# Altium schematic sheet Buck 5V.SchDoc
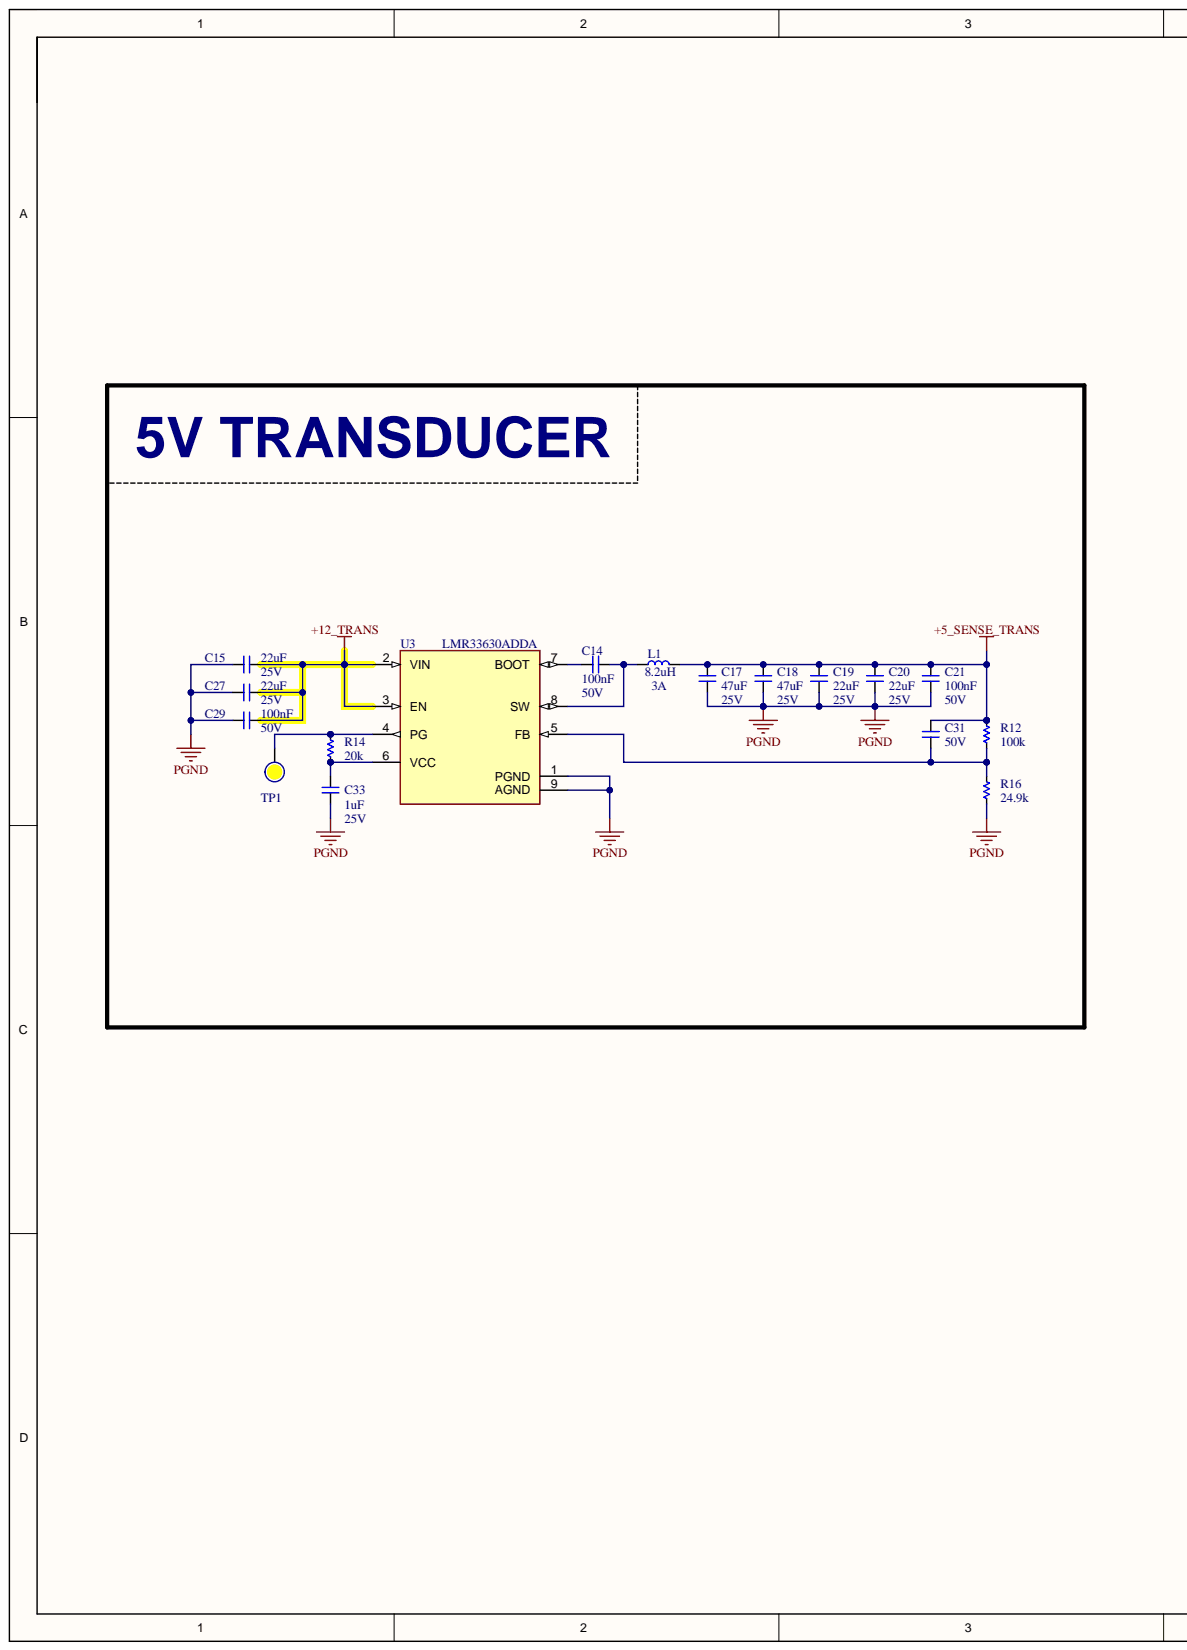
[diagram p0: Buck 5V.SchDoc, left]
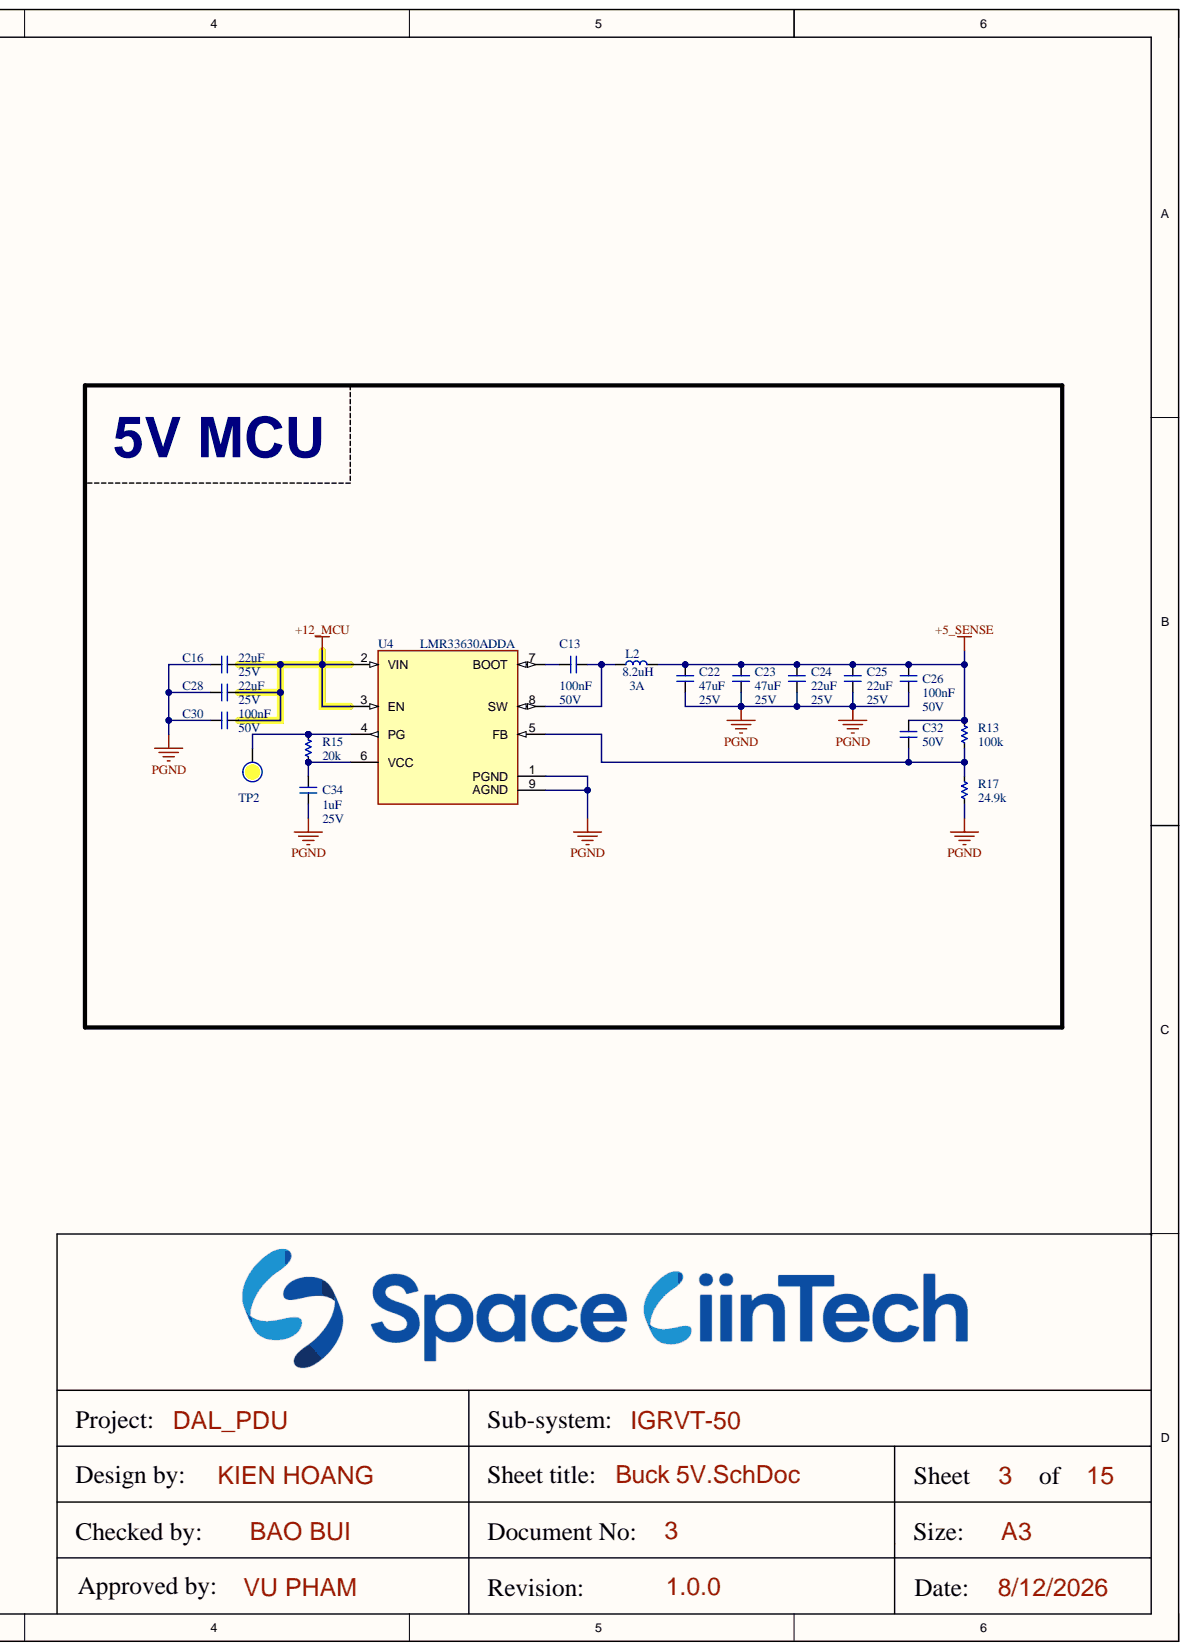
[diagram p1: Buck 5V.SchDoc, right]

## Parts
C13  100nF  [CAP_C_1608X80]
C14  100nF  Voltage: 50V  [CAP_C_1608X80]
C15  22uF  Voltage: 25V  [CAP_C_2012X110]
C16  22uF  Voltage: 25V  [CAP_C_2012X110]
C17  47uF  Voltage: 25V  [CAP_C_3216X140]
C18  47uF  Voltage: 25V  [CAP_C_3216X140]
C19  22uF  Voltage: 25V  [CAP_C_2012X110]
C20  22uF  Voltage: 25V  [CAP_C_2012X110]
C21  100nF  Voltage: 50V  [CAP_C_1608X80]
C22  47uF  Voltage: 25V  [CAP_C_3216X140]
C23  47uF  Voltage: 25V  [CAP_C_3216X140]
C24  22uF  Voltage: 25V  [CAP_C_2012X110]
C25  22uF  Voltage: 25V  [CAP_C_2012X110]
C26  100nF  Voltage: 50V  [CAP_C_1608X80]
C27  22uF  Voltage: 25V  [CAP_C_2012X110]
C28  22uF  Voltage: 25V  [CAP_C_2012X110]
C29  100nF  Voltage: 50V  [CAP_C_1608X80]
C30  100nF  Voltage: 50V  [CAP_C_1608X80]
C31  Voltage: 50V  [CAP_C_1608X80]  (hidden comment: DNP/100nF)
C32  100nF  Voltage: 50V  [CAP_C_1608X80]
C33  1uF  Voltage: 25V  [CAP_C_1608X80]
C34  1uF  Voltage: 25V  [CAP_C_1608X80]
L1  8.2uH  Voltage: 3A  [INDUCTOR 6363]
L2  8.2uH  Voltage: 3A  [INDUCTOR 6363]
R12  100k  [RES_C_1608X60]
R13  100k  [RES_C_1608X60]
R14  20k  [RES_C_1608X60]
R15  20k  [RES_C_1608X60]
R16  24.9k  [RES_C_1608X60]
R17  24.9k  [RES_C_1608X60]
TP1  (no value)  [TP_1mm_SMD]  (no BOM)
TP2  (no value)  [TP_1mm_SMD]  (no BOM)
U3  LMR33630ADDA  [HSOIC_8]
U4  LMR33630ADDA  [HSOIC_8]

## Nets
+5_SENSE: C22.1 C23.1 C24.1 C25.1 C26.1 C32.1 L2.2 R13.1
+5_SENSE_TRANS: C17.1 C18.1 C19.1 C20.1 C21.1 C31.1 L1.2 R12.1
+12_MCU: C16.1 C28.1 C30.1 U4.2(VIN) U4.3(EN)
+12_TRANS: C15.1 C27.1 C29.1 U3.2(VIN) U3.3(EN)
PGND: C15.2 C16.2 C17.2 C18.2 C19.2 C20.2 C21.2 C22.2 C23.2 C24.2 C25.2 C26.2 C27.2 C28.2 C29.2 C30.2 C33.2 C34.2 R16.2 R17.2 U3.1(PGND) U3.9(AGND) U4.1(PGND) U4.9(AGND)
NetC13_1: C13.1 L2.1 U4.8(SW)
NetC13_2: C13.2 U4.7(BOOT)
NetC14_1: C14.1 L1.1 U3.8(SW)
NetC14_2: C14.2 U3.7(BOOT)
NetC31_2: C31.2 R12.2 R16.1 U3.5(FB)
NetC32_2: C32.2 R13.2 R17.1 U4.5(FB)
NetC33_1: C33.1 R14.2 U3.6(VCC)
NetC34_1: C34.1 R15.2 U4.6(VCC)
NetR14_1: R14.1 TP1.1 U3.4(PG)
NetR15_1: R15.1 TP2.1 U4.4(PG)

## Library data of the parts
C13 = _CAP_C_2: CAP; Voltage: 50V; Component Kind: Standard; Library Name: LABLIB.SchLib
C14-C34 = _CAP_C_2: CAP; Component Kind: Standard; Library Name: LABLIB.SchLib
L1 L2 = Inductor: Component Kind: Standard; Description: Inductor; Library Name: KIENASIY123_LIB.IntLib
R12-R17 = _RES_B_2_2: RES; Component Kind: Standard; Library Name: LABLIB.SchLib
TP1 TP2 = Test Point: Solder: NF; Description: Test Point; Library Name: LABLIB.SchLib
U3 U4 = LMR33630ADDA: Component Kind: Standard; Library Name: new1.SchLib
